# Altium schematic sheet 02_POWER_AUXPOWER.SchDoc
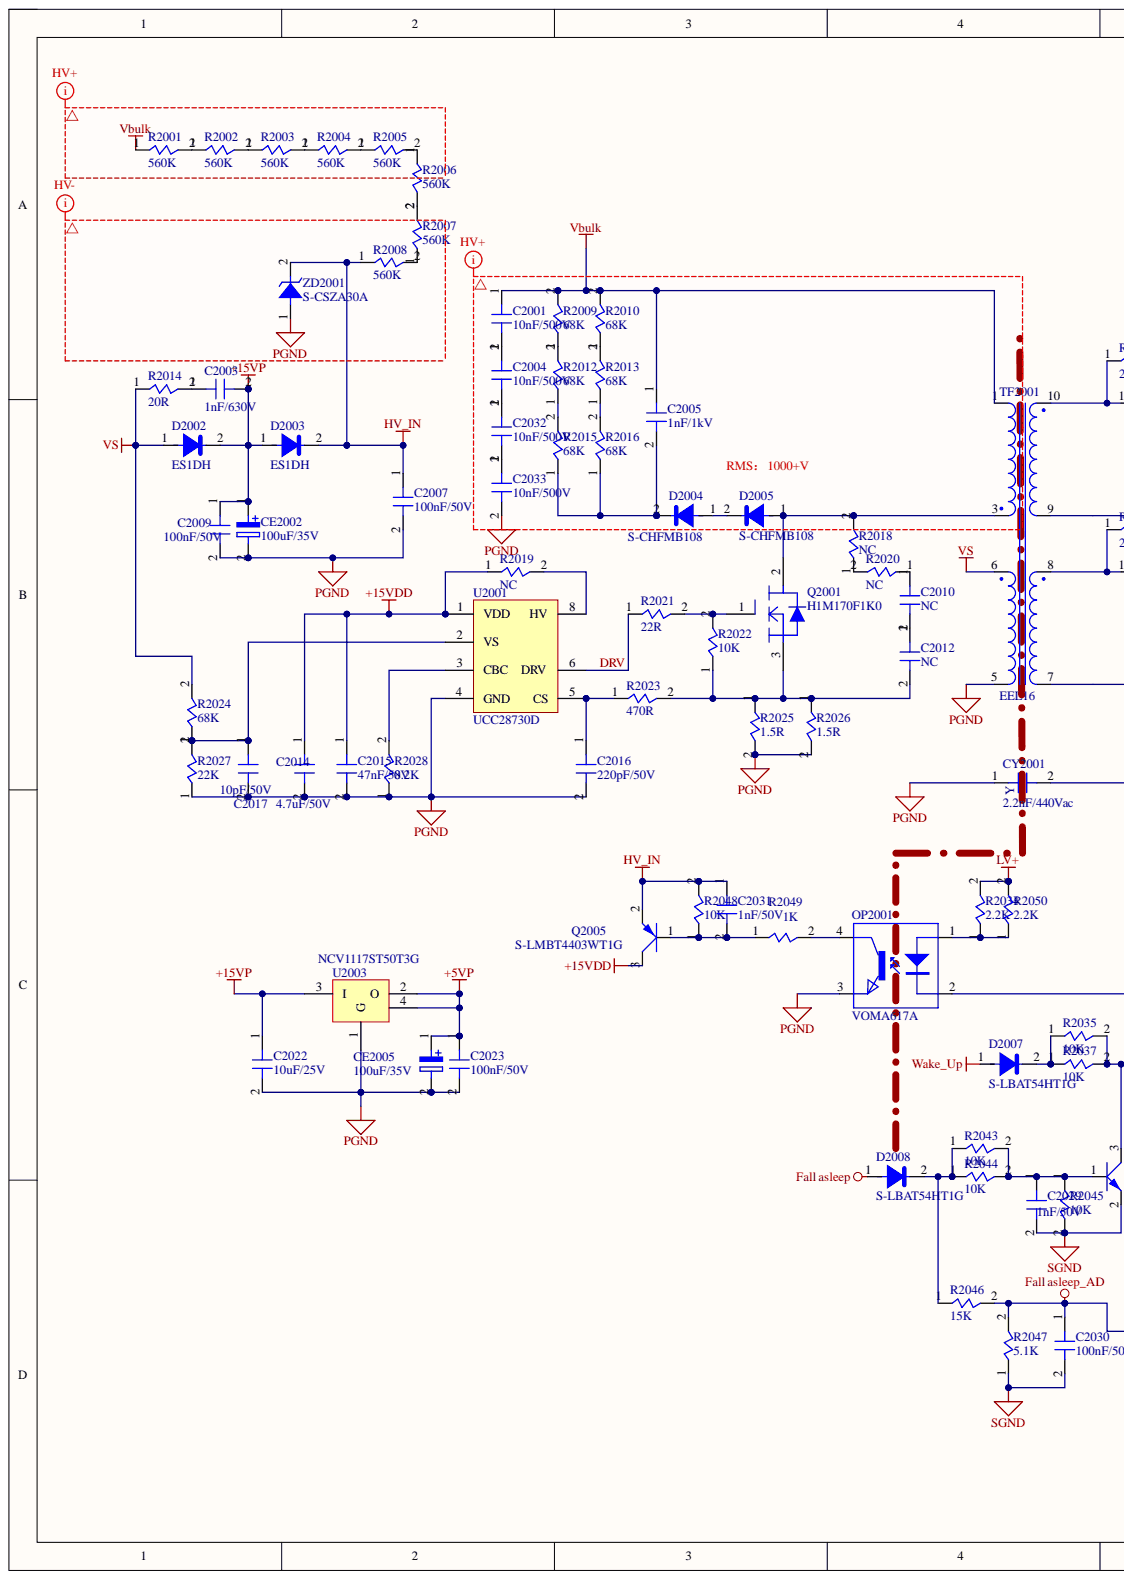
[diagram p0: 02_POWER_AUXPOWER.SchDoc, left]
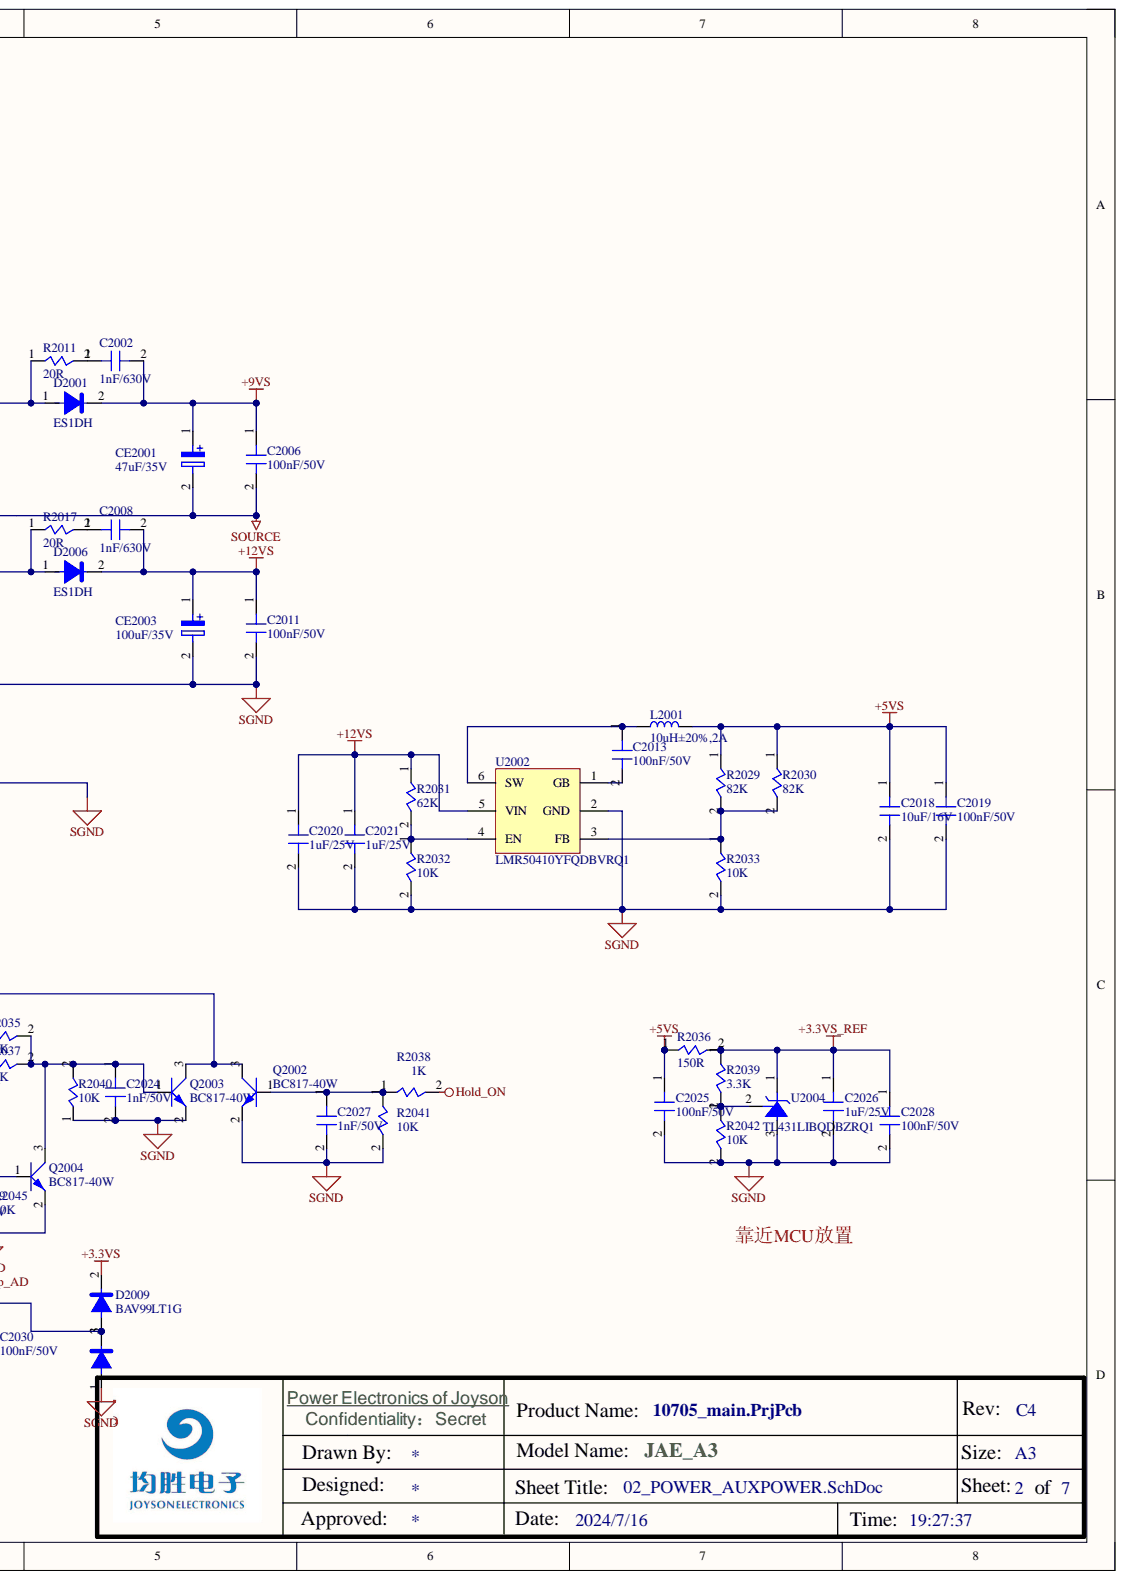
[diagram p1: 02_POWER_AUXPOWER.SchDoc, right]

## Parts
C2001  10nF/500V  [C-1206]
C2002  1nF/630V  [C-1206]
C2003  1nF/630V  [C-1206]
C2004  10nF/500V  [C-1206]
C2005  1nF/1KV  [C-1206]
C2006  100nF  value 100nF/50V  [C-0603]
C2007  100nF  value 100nF/50V  [C-0603]
C2008  1nF/630V  [C-1206]
C2009  100nF  value 100nF/50V  [C-0603]
C2010  NC  [C-1206]  (no BOM)
C2011  100nF  value 100nF/50V  [C-0603]
C2012  NC  [C-1206]  (no BOM)
C2013  100nF  value 100nF/50V  [C-0603]
C2014  4.7uF  value 4.7uF/50V  [C-1206]
C2015  47nF  value 47nF/50V  [C-0603]
C2016  220pF  value 220pF/50V  [C-0603]
C2017  10pF  value 10pF/50V  [C-0402]
C2018  10uF  value 10uF/16V  [C-0805]
C2019  100nF  value 100nF/50V  [C-0603]
C2020  1uF  value 1uF/25V  [C-0603]
C2021  1uF  value 1uF/25V  [C-0603]
C2022  10uF  value 10uF/25V  [C-1206]
C2023  100nF  value 100nF/50V  [C-0603]
C2024  1nF  value 1nF/50V  [C-0603]
C2025  100nF  value 100nF/50V  [C-0603]
C2026  1uF  value 1uF/25V  [C-0603]
C2027  1nF  value 1nF/50V  [C-0402]
C2028  100nF  value 100nF/50V  [C-0603]
C2029  1nF  value 1nF/50V  [C-0402]
C2030  100nF  value 100nF/50V  [C-0402]
C2031  1nF  value 1nF/50V  [C-0603]
C2032  10nF/500V  [C-1206]
C2033  10nF/500V  [C-1206]
CE2001  47uF/35V  [CET-6.3*7.7]
CE2002  100uF/35V  [CET-6.3*7.7]
CE2003  100uF/35V  [CET-6.3*7.7]
CE2005  100uF/35V  [CET-6.3*7.7]
CY2001  2.2nF/440Vac  [CY-17.5*6*12-P15]
D2001  ES1DH  [SMA]
D2002  ES1DH  [SMA]
D2003  ES1DH  [SMA]
D2004  S-CHFMB108  [SMB]
D2005  S-CHFMB108  [SMB]
D2006  ES1DH  [SMA]
D2007  S-LBAT54HT1G  [SOD-323]
D2008  S-LBAT54HT1G  [SOD-323]
D2009  BAV99LT1G  [SOT-23]
L2001  10uH±20%,2A  [L_TMPC0603H]
OP2001  VOMA617A  [SOP-4N]
Q2001  H1M170F1K0  [TO-247-3-vpad]
Q2002  BC817-40W  [SOT-323]
Q2003  BC817-40W  [SOT-323]
Q2004  BC817-40W  [SOT-323]
Q2005  S-LMBT4403WT1G  [SOT-323]
R2001  560K  [R-1206]
R2002  560K  [R-1206]
R2003  560K  [R-1206]
R2004  560K  [R-1206]
R2005  560K  [R-1206]
R2006  560K  [R-1206]
R2007  560K  [R-1206]
R2008  560K  [R-1206]
R2009  68K  [R-1206]
R2010  68K  [R-1206]
R2011  20R  [R-1206]
R2012  68K  [R-1206]
R2013  68K  [R-1206]
R2014  20R  [R-1206]
R2015  68K  [R-1206]
R2016  68K  [R-1206]
R2017  20R  [R-1206]
R2018  NC  [R-1206]  (no BOM)
R2019  NC  [R-0805]  (no BOM)
R2020  NC  [R-1206]  (no BOM)
R2021  22R  [R-1206]
R2022  10K  [R-0402]
R2023  470R  [R-1206]
R2024  68K  [R-0402]
R2025  1.5R  [R-1206]
R2026  1.5R  [R-1206]
R2027  22K  [R-0402]
R2028  8.2K  [R-0603]
R2029  82K  [R-0402]
R2030  82K  [R-0402]
R2031  62K  [R-0402]
R2032  10K  [R-0402]
R2033  10K  [R-0402]
R2034  2.2K  [R-1206]
R2035  10K  [R-0603]
R2036  150R  [R-1206]
R2037  10K  [R-0603]
R2038  1K  [R-0402]
R2039  3.3K  [R-0402]
R2040  10K  [R-0402]
R2041  10K  [R-0402]
R2042  10K  [R-0402]
R2043  10K  [R-0603]
R2044  10K  [R-0603]
R2045  10K  [R-0402]
R2046  15K  [R-1206]
R2047  5.1K  [R-1206]
R2048  10K  [R-0402]
R2049  1K  [R-0402]
R2050  2.2K  [R-1206]
TF2001  EEL16  [EEL16 4+6]
U2001  UCC28730D  [SOP-7N]
U2002  LMR50410YFQDBVRQ1  [SOT-23-6]
U2003  NCV1117ST50T3G  [SOT-223]
U2004  TL431LIBQDBZRQ1  [SOT-23]
ZD2001  S-CSZA30A  [SMA]

## Nets
+3.3VS: D2009.2
+3.3VS_REF: C2026.1 C2028.1 R2036.2 R2039.1 U2004.1
+5VP: C2023.1 CE2005.1 U2003.2(O) U2003.4
+5VS: C2018.1 C2019.1 C2025.1 L2001.2 R2029.1 R2030.1 R2036.1
+9VS: C2002.2 C2006.1 CE2001.1 D2001.2
+12VS: C2008.2 C2011.1 C2020.1 C2021.1 CE2003.1 D2006.2 R2031.1 U2002.5(VIN)
+15VDD: C2014.1 C2015.1 Q2005.3 R2019.1 U2001.1(VDD)
+15VP: C2003.2 C2009.1 C2022.1 CE2002.1 D2002.2 D2003.1 U2003.3(I)
Fall asleep: D2008.1
Fall asleep_AD: C2030.1 D2009.3 R2046.2 R2047.2
Hold_ON: R2038.2
HV_IN: C2007.1 C2031.1 D2003.2 Q2005.2 R2008.1 R2048.2 ZD2001.2
LV+: R2034.2 R2050.2
PGND: C2007.2 C2009.2 C2014.2 C2015.2 C2016.2 C2017.2 C2022.2 C2023.2 C2033.2 CE2002.2 CE2005.2 CY2001.1 OP2001.3 R2025.2 R2026.2 R2027.1 R2028.1 TF2001.5 U2001.4(GND) U2003.1(G) ZD2001.1
SGND: C2011.2 C2018.2 C2019.2 C2020.2 C2021.2 C2024.2 C2025.2 C2026.2 C2027.2 C2028.2 C2029.2 C2030.2 CE2003.2 CY2001.2 D2009.1 Q2002.2 Q2003.2 Q2004.2 R2032.2 R2033.2 R2040.1 R2041.2 R2042.2 R2045.2 R2047.1 TF2001.7 U2002.2(GND) U2004.3
SOURCE: C2006.2 CE2001.2 TF2001.9
Vbulk: C2001.1 C2005.1 R2001.1 R2009.2 R2010.2 TF2001.1
VS: D2002.1 R2014.1 R2024.2 TF2001.6
Wake_Up: D2007.1
DRV: R2021.1 U2001.6(DRV)
NetC2001_2: C2001.2 C2004.1
NetC2002_1: C2002.1 R2011.2
NetC2003_1: C2003.1 R2014.2
NetC2004_2: C2004.2 C2032.1
NetC2005_2: C2005.2 D2004.2 R2015.1 R2016.1
NetC2008_1: C2008.1 R2017.2
NetC2010_1: C2010.1 R2020.1
NetC2010_2: C2010.2 C2012.1
NetC2012_2: C2012.2 Q2001.3 R2022.1 R2023.2 R2025.1 R2026.1
NetC2013_1: C2013.1 L2001.1 U2002.6(SW)
NetC2013_2: C2013.2 U2002.1(GB)
NetC2016_1: C2016.1 R2023.1 U2001.5(CS)
NetC2017_1: C2017.1 R2024.1 R2027.2 U2001.2(VS)
NetC2024_1: C2024.1 Q2003.1 Q2004.3 R2035.2 R2037.2 R2040.2
NetC2027_1: C2027.1 Q2002.1 R2038.1 R2041.1
NetC2029_1: C2029.1 Q2004.1 R2043.2 R2044.2 R2045.1
NetC2031_2: C2031.2 Q2005.1 R2048.1 R2049.1
NetC2032_2: C2032.2 C2033.1
NetD2001_1: D2001.1 R2011.1 TF2001.10
NetD2004_1: D2004.1 D2005.2
NetD2005_1: D2005.1 Q2001.2 R2018.2 TF2001.3
NetD2006_1: D2006.1 R2017.1 TF2001.8
NetD2007_2: D2007.2 R2035.1 R2037.1
NetD2008_2: D2008.2 R2043.1 R2044.1 R2046.1
NetOP2001_1: OP2001.1 R2034.1 R2050.1
NetOP2001_2: OP2001.2 Q2002.3 Q2003.3
NetOP2001_4: OP2001.4 R2049.2
NetQ2001_1: Q2001.1 R2021.2 R2022.2
NetR2001_2: R2001.2 R2002.1
NetR2002_2: R2002.2 R2003.1
NetR2003_2: R2003.2 R2004.1
NetR2004_2: R2004.2 R2005.1
NetR2005_2: R2005.2 R2006.1
NetR2006_2: R2006.2 R2007.2
NetR2007_1: R2007.1 R2008.2
NetR2009_1: R2009.1 R2012.2
NetR2010_1: R2010.1 R2013.2
NetR2012_1: R2012.1 R2015.2
NetR2013_1: R2013.1 R2016.2
NetR2018_1: R2018.1 R2020.2
NetR2019_2: R2019.2 U2001.8(HV)
NetR2028_2: R2028.2 U2001.3(CBC)
NetR2029_2: R2029.2 R2030.2 R2033.1 U2002.3(FB)
NetR2031_2: R2031.2 R2032.1 U2002.4(EN)
NetR2039_2: R2039.2 R2042.1 U2004.2

## Library data of the parts
C2001 C2004 C2032 C2033 = 1101042019-00: 贴片电容封装; Brand: YAGEO; Database Table Name: Capacitor-SMD; Grade: 汽车级; Material Name: Capacitor; Spec/Modle: Capacitor-MLCC-1206-X7R-±10%-500V-10nF; Temprature: -55℃ to +125℃; Type: 贴片; Vendor No: AC1206KKX7RBB103; Component Kind: Standard; Library Name: Database_Libs1.DbLib; Material No: 1101042019-00; Value: 10nF/500V; Value2: 10nF/500V
C2002 C2003 C2008 = 1101040025-00: 贴片电容封装; Brand: YAGEO; Database Table Name: Capacitor-SMD; Grade: 汽车级; Material Name: Capacitor; Spec/Modle: Capacitor-MLCC-1206-NPO-±5%-630V-1nF; Temprature: -55℃ to +125℃; Type: 贴片; Vendor No: AC1206JKNPOZBN102; Component Kind: Standard; Library Name: Database_Libs1.DbLib; Material No: 1101040025-00; Value: 1nF/630V; Value2: 1nF/630V
C2005 C2010 C2012 = 1101042007-00: 贴片电容封装; Brand: YAGEO; Database Table Name: Capacitor-SMD; Grade: 汽车级; Material Name: Capacitor; Spec/Modle: Capacitor-MLCC-1206-X7R-±10%-1000V-1nF; Temprature: -55℃ to +125℃; Type: 贴片; Vendor No: AC1206KKX7RCBB102; Library Name: Database_Libs1.DbLib; Material No: 1101042007-00
  C2005: Component Kind: Standard; Value: 1nF/1kV; Value2: 1nF/1KV
  C2010 C2012: Value: NC; Value2: NC
C2006 C2007 C2009 C2011 C2013 C2019 C2023 C2025 C2028 = 1101022028-00: 贴片电容封装; Brand: YAGEO; Database Table Name: Capacitor-SMD; Grade: 汽车级; Material Name: Capacitor; SAP Number: 05521-133/0000; Spec/Modle: Capacitor-MLCC-0603-X7R-±10%-50V-100nF; Temprature: -55℃ to +125℃; Type: 贴片; Vendor No: AC0603KRX7R9BB104; Component Kind: Standard; Library Name: Database_Libs1.DbLib; Material No: 1101022028-00; Value2: 100nF
C2014 = 1101042016-00: 贴片电容封装; Brand: YAGEO; Database Table Name: Capacitor-SMD; Grade: 汽车级; Material Name: Capacitor; Spec/Modle: Capacitor-MLCC-1206-X7R-±10%-50V-4.7uF; Temprature: -55℃ to +125℃; Type: 贴片; Vendor No: AC1206KKX7R9BB475; Component Kind: Standard; Library Name: Database_Libs1.DbLib; Material No: 1101042016-00; Value2: 4.7uF
C2015 = 1101022024-00: 贴片电容封装; Brand: YAGEO; Database Table Name: Capacitor-SMD; Grade: 汽车级; Material Name: Capacitor; SAP Number: 05521-242/0001; Spec/Modle: Capacitor-MLCC-0603-X7R-±10%-50V-47nF; Temprature: -55℃ to +125℃; Type: 贴片; Vendor No: AC0603KRX7R9BB473; Component Kind: Standard; Library Name: Database_Libs1.DbLib; Material No: 1101022024-00; Value2: 47nF
C2016 = 1101020007-00: 贴片电容封装; Brand: YAGEO; Database Table Name: Capacitor-SMD; Grade: 汽车级; Material Name: Capacitor; Spec/Modle: Capacitor-MLCC-0603-NPO-±5%-50V-220pF; Temprature: -55℃ to +125℃; Type: 贴片; Vendor No: AC0603JRNPO9BN221; Component Kind: Standard; Library Name: Database_Libs1.DbLib; Material No: 1101020007-00; Value2: 220pF
C2017 = 1101010001-00: 贴片电容封装; Brand: YAGEO; Database Table Name: Capacitor-SMD; Grade: 汽车级; Material Name: Capacitor; SAP Number: 09801-019/0000; Spec/Modle: Capacitor-MLCC-0402-NPO-±5%-50V-10pF; Temprature: -55℃ to +125℃; Type: 贴片; Vendor No: AC0402JRNPO9BN100; Component Kind: Standard; Library Name: Database_Libs1.DbLib; Material No: 1101010001-00; Value2: 10pF
C2018 = 1101032040-00: 贴片电容封装; Brand: YAGEO; Database Table Name: Capacitor-SMD; Grade: 汽车级; Material Name: Capacitor; Spec/Modle: Capacitor-MLCC-0805-X7R-±10%-16V-10uF; Temprature: -55℃ to +125℃; Type: 贴片; Vendor No: AC0805KKX7R7BB106; Component Kind: Standard; Library Name: Database_Libs1.DbLib; Material No: 1101032040-00; Value2: 10uF
C2020 C2021 C2026 = 1101022035-00: 贴片电容封装; Brand: YAGEO; Database Table Name: Capacitor-SMD; Grade: 汽车级; Material Name: Capacitor; Spec/Modle: Capacitor-MLCC-0603-X7R-±10%-25V-1uF; Temprature: -55℃ to +125℃; Type: 贴片; Vendor No: AC0603KPX7R8BB105; Component Kind: Standard; Library Name: Database_Libs1.DbLib; Material No: 1101022035-00; Value2: 1uF
C2022 = 1101042015-00: 贴片电容封装; Brand: YAGEO; Database Table Name: Capacitor-SMD; Grade: 汽车级; Material Name: Capacitor; Spec/Modle: Capacitor-MLCC-1206-X7R-±10%-25V-10uF; Temprature: -55℃ to +125℃; Type: 贴片; Vendor No: AC1206KKX7R8BB106; Component Kind: Standard; Library Name: Database_Libs1.DbLib; Material No: 1101042015-00; Value2: 10uF
C2024 C2031 = 1101020014-00: 贴片电容封装; Brand: YAGEO; Database Table Name: Capacitor-SMD; Grade: 汽车级; Material Name: Capacitor; Spec/Modle: Capacitor-MLCC-0603-NPO-±5%-50V-1nF; Temprature: -55℃ to +125℃; Type: 贴片; Vendor No: AC0603JRNPO9BN102; Component Kind: Standard; Library Name: Database_Libs1.DbLib; Material No: 1101020014-00; Value2: 1nF
C2027 C2029 = 1101012010-00: 贴片电容封装; Brand: YAGEO; Database Table Name: Capacitor-SMD; Grade: 汽车级; Material Name: Capacitor; SAP Number: 09801-025/0000; Spec/Modle: Capacitor-MLCC-0402-X7R-±10%-50V-1nF; Temprature: -55℃ to +125℃; Type: 贴片; Vendor No: AC0402KRX7R9BB102; Component Kind: Standard; Library Name: Database_Libs1.DbLib; Material No: 1101012010-00; Value2: 1nF
C2030 = 1101012028-00: 贴片电容封装; Brand: YAGEO; Database Table Name: Capacitor-SMD; Grade: 汽车级; Material Name: Capacitor; SAP Number: 09701-715/0000; Spec/Modle: Capacitor-MLCC-0402-X7R-±10%-50V-100nF; Temprature: -55℃ to +125℃; Type: 贴片; Vendor No: AC0402KRX7R9BB104; Component Kind: Standard; Library Name: Database_Libs1.DbLib; Material No: 1101012028-00; Value2: 100nF
CE2001-CE2003 CE2005 = 1103052001-00: 铝电解电容封装; Brand: LELON; Database Table Name: Capacitor-ELE; ESR: 35mΩ; Grade: 工业级; Material Name: Capacitor; Ripple Current: 1700mA; SAP Number: 06005-521/0000; Spec/Modle: Capacitor-EC-HBO-35V-100uF-±20%-4000h-φ6.3*7.7-P2; Temprature: -55℃ to +125℃; Vendor No: HBO101M1VTR-0608; Component Kind: Standard; Library Name: Database_Libs1.DbLib; Material No: 1103052001-00; Type: 贴片
  CE2001: Value: 47uF/35V
  CE2002 CE2003 CE2005: Value: 100uF/35V
CY2001 = 1105030001-00: Y电容封装; Brand: 法拉; Database Table Name: Capacitor-RAD; Grade: 汽车级; Material Name: Capacitor; Spec/Modle: Capacitor-Y1-MKP-440Vac-2.2nF-±10%-W*T*H=17.5*6*12-P15-L5; Temprature: -40℃ to +110℃; Vendor No: D47S1222K; Component Kind: Standard; Library Name: Database_Libs1.DbLib; Material No: 1105030001-00; Type: 插件; Value: 2.2nF/440Vac
D2001-D2003 D2006 = 1205090001-00: 二极管SMD-2P封装; Brand: TSC; Database Table Name: Diode; Grade: 工业级; SAP Number: 06005-196/0000; Spec/Modle: Diode-SFR-SMA-DO214AC-200V-1A-mark(ES1D); Temprature: -55℃ to +150℃; Type: 贴片; Component Kind: Standard; Library Name: Database_Libs1.DbLib; Material Name: Diode; Material No: 1205090001-00; Value: ES1DH; Vendor No: ES1DH
D2004 D2005 = 1201090004-00: 二极管SMD-2P封装; Brand: LRC; Database Table Name: Diode; Grade: 汽车级; Spec/Modle: Diode-SMD-SMB-1000V-1A; Temprature: -50℃ to +150℃; Type: 贴片; Component Kind: Standard; Library Name: Database_Libs1.DbLib; Material Name: Diode; Material No: 1201090004-00; Value: S-CHFMB108; Vendor No: S-CHFMB108
D2007 D2008 = 1203020002-00: 二极管SMD-2P封装; Brand: LRC; Database Table Name: Diode; Grade: 工业级; SAP Number: 06005-408/0000; Spec/Modle: Diode-Schottky-SMD-SOD323-30V-200mA-mark(JV); Temprature: -55℃ to +125℃; Type: 贴片; Component Kind: Standard; Library Name: Database_Libs1.DbLib; Material Name: Diode; Material No: 1203020002-00; Value: S-LBAT54HT1G; Vendor No: S-LBAT54HT1G
D2009 = 1203060001-00: 二极管SOT23串联封装; Brand: onsemi; Database Table Name: Diode; Grade: 工业级; SAP Number: 06005-404/0000; Spec/Modle: Diode-Schottky-SOT23-100V-0.7A-mark(A7M); Temprature: -65℃ to +150℃; Type: 贴片; Component Kind: Standard; Library Name: Database_Libs1.DbLib; Material Name: Diode; Material No: 1203060001-00; Value: BAV99LT1G; Vendor No: BAV99LT1G
L2001 = L-0805: Brand: VISHAY; Material Name: Inductor; SAP Number: 06005-384/0000; Spec/Modle: SMD,INDUCTOR,10uH±20%,2A,182.8mOhm; Vendor No: IHLP2020BZER100M11; Component Kind: Standard; Library Name: my lib.schlib
OP2001 = 1901010004-00: 光耦封装; Brand: VISHAY; Database Table Name: Other; Grade: 汽车级; Material name: Optical coupler; Specification: Optocoupler-SOP4W-CTI(175); Temprature: -40℃ to +110℃; Component Kind: Standard; Library Name: Database_Libs1.DbLib; Material No: 1901010004-00; Order code: VOMA617A; Type: 贴片; Value: VOMA617A
Q2001 = 1304220002-00: NMOS管TO3P封装; Brand: HestiaPower; Database Table Name: Transistor; Grade: 汽车级; Material Name: Mosfet; Spec/Modle: Mosfet-NMOS-TO247-3-1700V-3.6A-1Ω-mark(H1M170F1K0); Temprature: -55℃ to +175℃; Type: 插件; Component Kind: Standard; Library Name: Database_Libs1.DbLib; Material No: 1304220002-00; Value: H1M170F1K0; Vendor No: H1M170F1K0
Q2002-Q2004 = 1301030004-00: NPN三极管SOT23封装; Brand: nexperia; Database Table Name: Transistor; Grade: 工业级; Material Name: Mosfet; Spec/Modle: Transistor-NPN-SOT23-45V-500mA-hfe(250->600)-mark(6C); Temprature: -55℃ to +150℃; Type: 贴片; Component Kind: Standard; Library Name: Database_Libs1.DbLib; Material No: 1301030004-00; Value: BC817-40W; Vendor No: BC817-40W
Q2005 = 1302020001-00: PNP三极管SOT323封装; Brand: LRC; Database Table Name: Transistor; Grade: 汽车级; SAP Number: 06005-424/0000; Spec/Modle: Transistor-PNP-SOT323-(-40V)-600mA-hfe(100->300)-mark(2T); Temprature: -55℃ to +150℃; Type: 贴片; Component Kind: Standard; Library Name: Database_Libs1.DbLib; Material Name: Transistor; Material No: 1302020001-00; Value: S-LMBT4403WT1G; Vendor No: S-LMBT4403WT1G
R2001-R2008 = 1001040656-00: 贴片电阻封装; Brand: YAGEO; Database Table Name: Resistor-SMD; Grade: 汽车级; Material Name: Resistor; SAP Number: 05541-676/0000; Spec/Modle: Resistor-SMD-1206-1/4W-±1%-±100ppm/℃-560KΩ; Temprature: -55℃ to +155℃; Vendor No: AC1206FR-07560KL; Component Kind: Standard; Library Name: Database_Libs1.DbLib; Material No: 1001040656-00; Type: 贴片; Value: 560K
R2009 R2010 R2012 R2013 R2015 R2016 R2018 R2020 = 1001040552-00: 贴片电阻封装; Brand: YAGEO; Database Table Name: Resistor-SMD; Grade: 汽车级; Material Name: Resistor; SAP Number: 05541-572/0000; Spec/Modle: Resistor-SMD-1206-1/4W-±1%-±100ppm/℃-68KΩ; Temprature: -55℃ to +155℃; Vendor No: AC1206FR-0768KL; Library Name: Database_Libs1.DbLib; Material No: 1001040552-00; Type: 贴片
  R2009 R2010 R2012 R2013 R2015 R2016: Component Kind: Standard; Value: 68K
  R2018 R2020: Value: NC
R2011 R2014 R2017 = 1001040147-00: 贴片电阻封装; Brand: YAGEO; Database Table Name: Resistor-SMD; Grade: 汽车级; Material Name: Resistor; SAP Number: 05541-167/0000; Spec/Modle: Resistor-SMD-1206-1/4W-±1%-±100ppm/℃-20Ω; Temprature: -55℃ to +155℃; Vendor No: AC1206FR-0720RL; Component Kind: Standard; Library Name: Database_Libs1.DbLib; Material No: 1001040147-00; Type: 贴片; Value: 20R
R2019 = 1001030457-00: 贴片电阻封装; Brand: YAGEO; Database Table Name: Resistor-SMD; Grade: 汽车级; Material Name: Resistor; SAP Number: 05542-477/0000; Spec/Modle: Resistor-SMD-0805-1/8W-±1%-±100ppm/℃-10KΩ; Temprature: -55℃ to +155℃; Vendor No: AC0805FR-0710KL; Library Name: Database_Libs1.DbLib; Material No: 1001030457-00; Type: 贴片; Value: NC
R2021 = 1001040151-00: 贴片电阻封装; Brand: YAGEO; Database Table Name: Resistor-SMD; Grade: 汽车级; Material Name: Resistor; SAP Number: 05541-171/0000; Spec/Modle: Resistor-SMD-1206-1/4W-±1%-±100ppm/℃-22Ω; Temprature: -55℃ to +155℃; Vendor No: AC1206FR-0722RL; Component Kind: Standard; Library Name: Database_Libs1.DbLib; Material No: 1001040151-00; Type: 贴片; Value: 22R
R2022 R2032 R2033 R2040-R2042 R2045 R2048 = 1001010457-00: 贴片电阻封装; Brand: YAGEO; Database Table Name: Resistor-SMD; Grade: 汽车级; Material Name: Resistor; SAP Number: 05544-477/0000; Spec/Modle: Resistor-SMD-0402-1/16W-±1%-±100ppm/℃-10KΩ; Temprature: -55℃ to +155℃; Vendor No: AC0402FR-0710KL; Component Kind: Standard; Library Name: Database_Libs1.DbLib; Material No: 1001010457-00; Type: 贴片; Value: 10K
R2023 = 1001040305-00: 贴片电阻封装; Brand: YAGEO; Database Table Name: Resistor-SMD; Grade: 汽车级; Material Name: Resistor; SAP Number: 05541-325/0000; Spec/Modle: Resistor-SMD-1206-1/4W-±1%-±100ppm/℃-470Ω; Temprature: -55℃ to +155℃; Vendor No: AC1206FR-07470RL; Component Kind: Standard; Library Name: Database_Libs1.DbLib; Material No: 1001040305-00; Type: 贴片; Value: 470R
R2024 = 1001010552-00: 贴片电阻封装; Brand: YAGEO; Database Table Name: Resistor-SMD; Grade: 汽车级; Material Name: Resistor; SAP Number: 05544-572/0000; Spec/Modle: Resistor-SMD-0402-1/16W-±1%-±100ppm/℃-68KΩ; Temprature: -55℃ to +155℃; Vendor No: AC0402FR-0768KL; Component Kind: Standard; Library Name: Database_Libs1.DbLib; Material No: 1001010552-00; Type: 贴片; Value: 68K
R2025 R2026 = 1001040019-00: 贴片电阻封装; Brand: YAGEO; Database Table Name: Resistor-SMD; Grade: 汽车级; Material Name: Resistor; SAP Number: 05541-039/0000; Spec/Modle: Resistor-SMD-1206-1/4W-±1%-±200ppm/℃-1.5Ω; Temprature: -55℃ to +155℃; Vendor No: AC1206FR-071R5L; Component Kind: Standard; Library Name: Database_Libs1.DbLib; Material No: 1001040019-00; Type: 贴片; Value: 1.5R
R2027 = 1001010493-00: 贴片电阻封装; Brand: YAGEO; Database Table Name: Resistor-SMD; Grade: 汽车级; Material Name: Resistor; SAP Number: 05544-513/0000; Spec/Modle: Resistor-SMD-0402-1/16W-±1%-±100ppm/℃-22KΩ; Temprature: -55℃ to +155℃; Vendor No: AC0402FR-0722KL; Component Kind: Standard; Library Name: Database_Libs1.DbLib; Material No: 1001010493-00; Type: 贴片; Value: 22K
R2028 = 1001020447-00: 贴片电阻封装; Brand: YAGEO; Database Table Name: Resistor-SMD; Grade: 汽车级; Material Name: Resistor; SAP Number: 05543-467/0000; Spec/Modle: Resistor-SMD-0603-1/10W-±1%-±100ppm/℃-8.2KΩ; Temprature: -55℃ to +155℃; Vendor No: AC0603FR-078K2L; Component Kind: Standard; Library Name: Database_Libs1.DbLib; Material No: 1001020447-00; Type: 贴片; Value: 8.2K
R2029 R2030 = 1001010561-00: 贴片电阻封装; Brand: YAGEO; Database Table Name: Resistor-SMD; Grade: 汽车级; Material Name: Resistor; SAP Number: 05544-581/0000; Spec/Modle: Resistor-SMD-0402-1/16W-±1%-±100ppm/℃-82KΩ; Temprature: -55℃ to +155℃; Vendor No: AC0402FR-0782KL; Component Kind: Standard; Library Name: Database_Libs1.DbLib; Material No: 1001010561-00; Type: 贴片; Value: 82K
R2031 = 1001010548-00: 贴片电阻封装; Brand: YAGEO; Database Table Name: Resistor-SMD; Grade: 汽车级; Material Name: Resistor; SAP Number: 05544-568/0000; Spec/Modle: Resistor-SMD-0402-1/16W-±1%-±100ppm/℃-62KΩ; Temprature: -55℃ to +155℃; Vendor No: AC0402FR-0762KL; Component Kind: Standard; Library Name: Database_Libs1.DbLib; Material No: 1001010548-00; Type: 贴片; Value: 62K
R2034 R2050 = 1001040379-00: 贴片电阻封装; Brand: YAGEO; Database Table Name: Resistor-SMD; Grade: 汽车级; Material Name: Resistor; SAP Number: 05541-399/0000; Spec/Modle: Resistor-SMD-1206-1/4W-±1%-±100ppm/℃-2.2KΩ; Temprature: -55℃ to +155℃; Vendor No: AC1206FR-072K2L; Component Kind: Standard; Library Name: Database_Libs1.DbLib; Material No: 1001040379-00; Type: 贴片; Value: 2.2K
R2035 R2037 R2043 R2044 = 1001020457-00: 贴片电阻封装; Brand: YAGEO; Database Table Name: Resistor-SMD; Grade: 汽车级; Material Name: Resistor; SAP Number: 05543-477/0000; Spec/Modle: Resistor-SMD-0603-1/10W-±1%-±100ppm/℃-10KΩ; Temprature: -55℃ to +155℃; Vendor No: AC0603FR-0710KL; Component Kind: Standard; Library Name: Database_Libs1.DbLib; Material No: 1001020457-00; Type: 贴片; Value: 10K
R2036 = 1001040247-00: 贴片电阻封装; Brand: YAGEO; Database Table Name: Resistor-SMD; Grade: 汽车级; Material Name: Resistor; SAP Number: 05541-267/0000; Spec/Modle: Resistor-SMD-1206-1/4W-±1%-±100ppm/℃-150Ω; Temprature: -55℃ to +155℃; Vendor No: AC1206FR-07150RL; Component Kind: Standard; Library Name: Database_Libs1.DbLib; Material No: 1001040247-00; Type: 贴片; Value: 150R
R2038 R2049 = 1001010343-00: 贴片电阻封装; Brand: YAGEO; Database Table Name: Resistor-SMD; Grade: 汽车级; Material Name: Resistor; SAP Number: 05544-363/0000; Spec/Modle: Resistor-SMD-0402-1/16W-±1%-±100ppm/℃-1KΩ; Temprature: -55℃ to +155℃; Vendor No: AC0402FR-071KL; Component Kind: Standard; Library Name: Database_Libs1.DbLib; Material No: 1001010343-00; Type: 贴片; Value: 1K
R2039 = 1001010400-00: 贴片电阻封装; Brand: YAGEO; Database Table Name: Resistor-SMD; Grade: 汽车级; Material Name: Resistor; SAP Number: 05544-420/0000; Spec/Modle: Resistor-SMD-0402-1/16W-±1%-±100ppm/℃-3.3KΩ; Temprature: -55℃ to +155℃; Vendor No: AC0402FR-073K3L; Component Kind: Standard; Library Name: Database_Libs1.DbLib; Material No: 1001010400-00; Type: 贴片; Value: 3.3K
R2046 = 1001040475-00: 贴片电阻封装; Brand: YAGEO; Database Table Name: Resistor-SMD; Grade: 汽车级; Material Name: Resistor; SAP Number: 05541-495/0000; Spec/Modle: Resistor-SMD-1206-1/4W-±1%-±100ppm/℃-15KΩ; Temprature: -55℃ to +155℃; Vendor No: AC1206FR-0715KL; Component Kind: Standard; Library Name: Database_Libs1.DbLib; Material No: 1001040475-00; Type: 贴片; Value: 15K
R2047 = 1001040423-00: 贴片电阻封装; Brand: YAGEO; Database Table Name: Resistor-SMD; Grade: 汽车级; Material Name: Resistor; SAP Number: 05541-443/0000; Spec/Modle: Resistor-SMD-1206-1/4W-±1%-±100ppm/℃-5.1KΩ; Temprature: -55℃ to +155℃; Vendor No: AC1206FR-075K1L; Component Kind: Standard; Library Name: Database_Libs1.DbLib; Material No: 1001040423-00; Type: 贴片; Value: 5.1K
TF2001 = 1505010010-00: 辅源变压器; Brand: 南京中策; Database Table Name: Magnetic; Grade: 工业级; Material Name: Inductor; Spec/Modle: Transformer-Power-EE16V(6+4)-8W-15VP-12VS; Temprature: -40℃ to +125℃; Component Kind: Standard; Library Name: Database_Libs1.DbLib; Material No: 1505010010-00; Type: 插件; Value: EEL16; Vendor No: EEL16
U2001 = 1401060004-00: 芯片，带高压启动，唤醒开关电源芯片; Brand: TI; Database Table Name: IC; Grade: 工业级; Spec/Modle: IC-Power Management-SOIC7-83kHz-VCC(9→35V)-mark(U28730); Temprature: -40℃ to +125℃; Component Kind: Standard; Library Name: Database_Libs1.DbLib; Material Name: IC; Material No: 1401060004-00; Type: 贴片; Value: UCC28730D; Vendor No: UCC28730D
U2002 = 1401060002-00: 芯片，DCDC控制芯片; Brand: IT; Database Table Name: IC; Grade: 汽车级; Spec/Modle: IC-Power Management-SOT23-6-2.1MHz-1A-3.3/5V-VCC(4-36V)-mark(4AYF); Temprature: -40℃ to +150℃; Component Kind: Standard; Library Name: Database_Libs1.DbLib; Material Name: IC; Material No: 1401060002-00; Type: 贴片; Value: LMR50410YFQDBVRQ1; Vendor No: LMR50410YFQDBVRQ1
U2003 = 1402010005-00: 芯片，LDO; Brand: onsemi; Database Table Name: IC; Grade: 汽车级; Spec/Modle: IC-LDO-SOT223-5V-1.0%-1A-VCC(20V)-mark(AYW1733V); Temprature: -40℃ to +125℃; Component Kind: Standard; Library Name: Database_Libs1.DbLib; Material Name: IC; Material No: 1402010005-00; Type: 贴片; Value: NCV1117ST50T3G; Vendor No: NCV1117ST50T3G
U2004 = AZ431AN: Brand: TI; Material Name: IC; SAP Number: 06005-438/0000; Spec/Modle: SMD,IC,Adjustable Shunt Regulators,SOT-23,2.5V; Component Kind: Standard; Library Name: my lib.schlib; Vendor No: TL431LIBQDBZRQ1
ZD2001 = 1207021011-00: 稳压管2pin封装; Brand: LRC; Database Table Name: Diode; Grade: 汽车级; Spec/Modle: Diode-Zener-SMA-1W-30V(29.7V→30.3V)-mark(Z30A); Temprature: -50℃ to +150℃; Component Kind: Standard; Library Name: Database_Libs1.DbLib; Material Name: Diode; Material No: 1207021011-00; Type: 贴片; Value: S-CSZA30A; Vendor No: S-CSZA30A
